# Altium schematic sheet LED Driver.SchDoc("LED Driver")
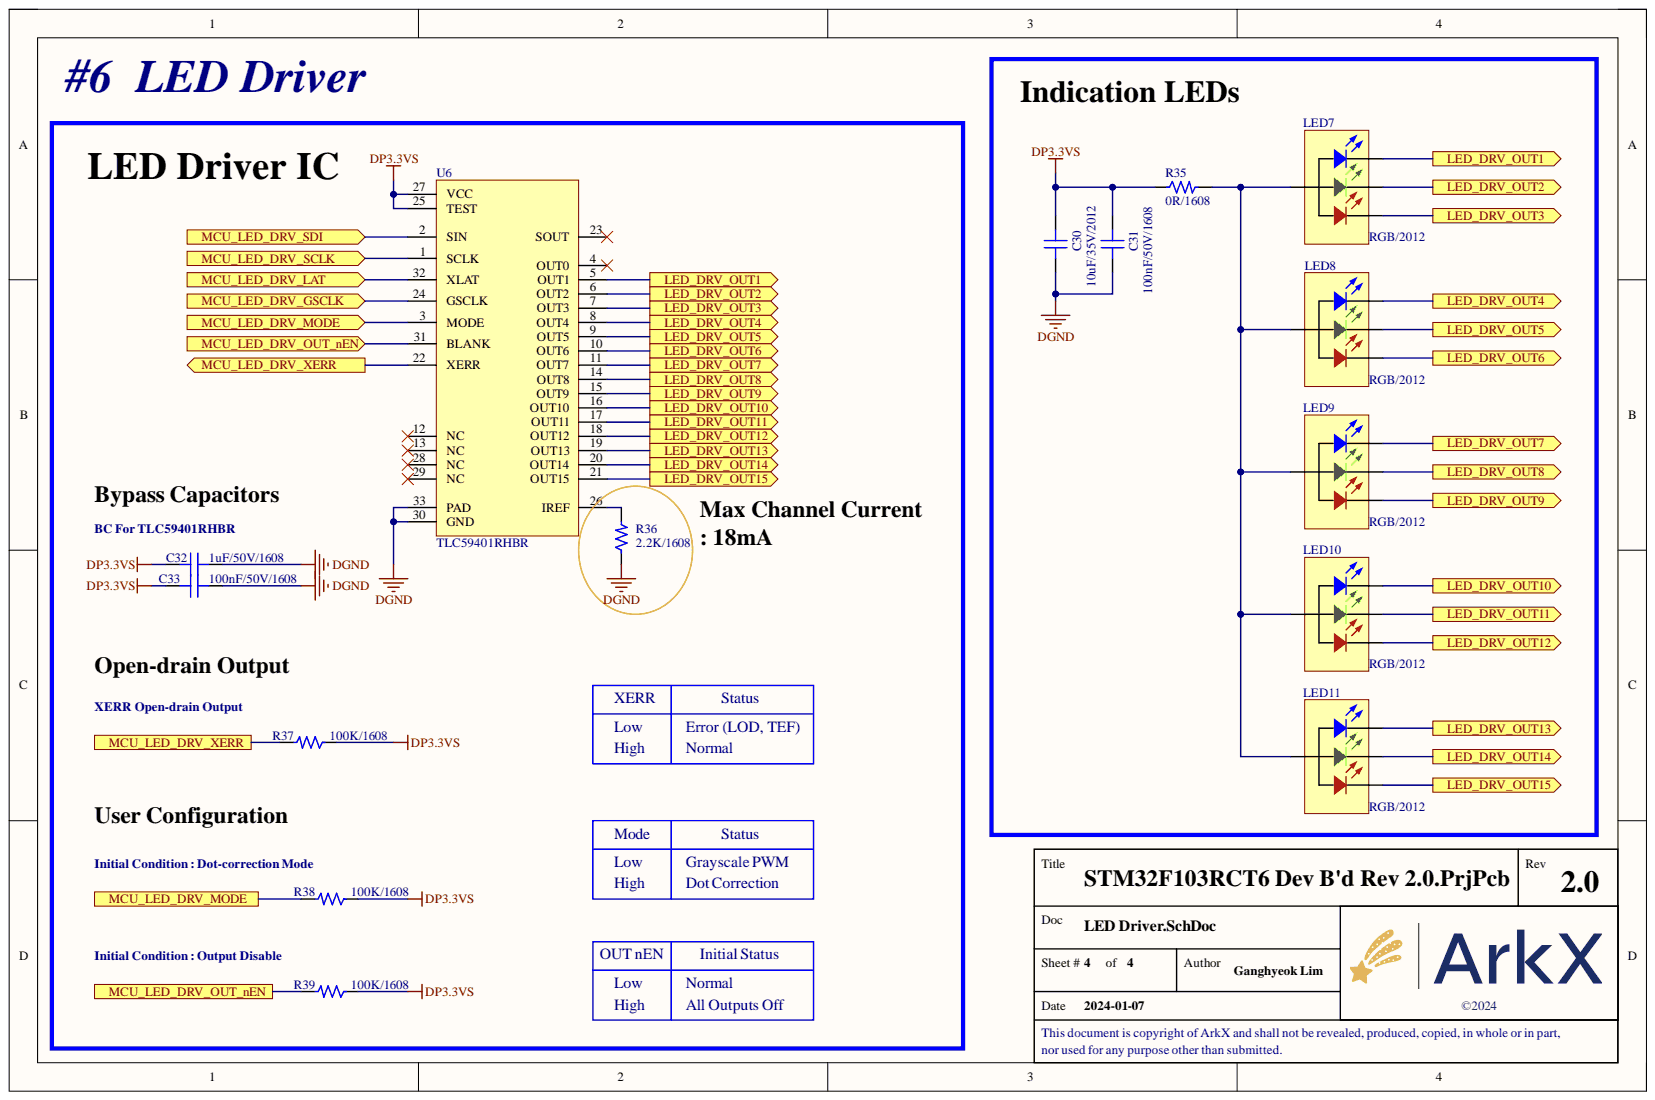
[diagram p0: LED Driver.SchDoc]

## Parts
C30  10uF/35V/2012  [CAP 2012 (0805_INCH)]
C31  100nF/50V/1608  [CAP 1608 (0603_INCH)]
C32  1uF/50V/1608  [CAP 1608 (0603_INCH)]
C33  100nF/50V/1608  [CAP 1608 (0603_INCH)]
LED7  RGB/2012  [LED MHT170RGBCT]
LED8  RGB/2012  [LED MHT170RGBCT]
LED9  RGB/2012  [LED MHT170RGBCT]
LED10  RGB/2012  [LED MHT170RGBCT]
LED11  RGB/2012  [LED MHT170RGBCT]
R35  0R/1608  [RES 1608 (0603_inch)]
R36  2.2K/1608  [RES 1608 (0603_inch)]
R37  100K/1608  [RES 1608 (0603_inch)]
R38  100K/1608  [RES 1608 (0603_inch)]
R39  100K/1608  [RES 1608 (0603_inch)]
U6  TLC59401RHBR  [VQFN-32 (TI drawing - RHB)]

## Ports
LED_DRV_OUT1, LED_DRV_OUT2, LED_DRV_OUT3, LED_DRV_OUT4, LED_DRV_OUT5, LED_DRV_OUT6, LED_DRV_OUT7, LED_DRV_OUT8, LED_DRV_OUT9, LED_DRV_OUT10, LED_DRV_OUT11, LED_DRV_OUT12, LED_DRV_OUT13, LED_DRV_OUT14, LED_DRV_OUT15, MCU_LED_DRV_GSCLK, MCU_LED_DRV_LAT, MCU_LED_DRV_MODE, MCU_LED_DRV_OUT_nEN, MCU_LED_DRV_SCLK, MCU_LED_DRV_SDI, MCU_LED_DRV_XERR

## Nets
DGND: C30.2 C31.1 C32.1 C33.1 R36.1 U6.30(GND) U6.33(PAD)
DP3.3VS: C30.1 C31.2 C32.2 C33.2 R35.1 R37.1 R38.1 R39.1 U6.25(TEST) U6.27(VCC)
LED_DRV_OUT1: LED7.3 U6.5(OUT1) ; port LED_DRV_OUT1
LED_DRV_OUT2: LED7.2 U6.6(OUT2) ; port LED_DRV_OUT2
LED_DRV_OUT3: LED7.1 U6.7(OUT3) ; port LED_DRV_OUT3
LED_DRV_OUT4: LED8.3 U6.8(OUT4) ; port LED_DRV_OUT4
LED_DRV_OUT5: LED8.2 U6.9(OUT5) ; port LED_DRV_OUT5
LED_DRV_OUT6: LED8.1 U6.10(OUT6) ; port LED_DRV_OUT6
LED_DRV_OUT7: LED9.3 U6.11(OUT7) ; port LED_DRV_OUT7
LED_DRV_OUT8: LED9.2 U6.14(OUT8) ; port LED_DRV_OUT8
LED_DRV_OUT9: LED9.1 U6.15(OUT9) ; port LED_DRV_OUT9
LED_DRV_OUT10: LED10.3 U6.16(OUT10) ; port LED_DRV_OUT10
LED_DRV_OUT11: LED10.2 U6.17(OUT11) ; port LED_DRV_OUT11
LED_DRV_OUT12: LED10.1 U6.18(OUT12) ; port LED_DRV_OUT12
LED_DRV_OUT13: LED11.3 U6.19(OUT13) ; port LED_DRV_OUT13
LED_DRV_OUT14: LED11.2 U6.20(OUT14) ; port LED_DRV_OUT14
LED_DRV_OUT15: LED11.1 U6.21(OUT15) ; port LED_DRV_OUT15
MCU_LED_DRV_GSCLK: U6.24(GSCLK) ; port MCU_LED_DRV_GSCLK
MCU_LED_DRV_LAT: U6.32(XLAT) ; port MCU_LED_DRV_LAT
MCU_LED_DRV_MODE: R38.2 U6.3(MODE) ; port MCU_LED_DRV_MODE
MCU_LED_DRV_OUT_nEN: R39.2 U6.31(BLANK) ; port MCU_LED_DRV_OUT_nEN
MCU_LED_DRV_SCLK: U6.1(SCLK) ; port MCU_LED_DRV_SCLK
MCU_LED_DRV_SDI: U6.2(SIN) ; port MCU_LED_DRV_SDI
MCU_LED_DRV_XERR: R37.2 U6.22(XERR) ; port MCU_LED_DRV_XERR
NetLED7_4: LED7.4 LED8.4 LED9.4 LED10.4 LED11.4 R35.2
NetR36_2: R36.2 U6.26(IREF)

Not connected: U6 4(OUT0) 12(NC) 13(NC) 23(SOUT) 28(NC) 29(NC)

## Library data of the parts
C30 = CAP_10uF/35V/2012: Ceramic CAP_10uF/35V/2012; Component Kind: Standard; JLCPCB Part Type: Basic Part; LCSC Part #: C440198; Library Name: Arkx.IntLib
C31 C33 = CAP_100nF/50V/1608: Ceramic CAP_100nF/50V/1608; Component Kind: Standard; JLCPCB Part Type: Basic Part; LCSC Part #: C14663; Library Name: Arkx.IntLib
C32 = CAP_1uF/50V/1608: Ceramic CAP_1uF/50V/1608; Component Kind: Standard; JLCPCB Part Type: Basic Part; LCSC Part #: C15849; Library Name: Arkx.IntLib
LED7-LED11 = LED_RGB_MHT170RGBCT: Colorless transparence -30℃~+85℃ Positive post Common anode RGB 120° 0805  Light Emitting Diodes (LED) ROHS; Component Kind: Standard; JLCPCB Part Ype: Extended Part; LCSC Part #: C2962094; Library Name: Arkx.IntLib
R35 = RES_0R/1608/0.1W: Power Rating: 0.1W; Precision: 1%; Value: 0R; Component Kind: Standard; Description: RES_0R/1608/0.1W; JLCPCB Part Type: Basic Part; LCSC Part #: C21189; Size: 1608; Library Name: Arkx.IntLib
R36 = RES_2.2K/1608/0.1W: Power Rating: 0.1W; Precision: 1%; Value: 2.2K; Component Kind: Standard; Description: RES_2.2K/1608/0.1W; JLCPCB Part Type: Basic Part; LCSC Part #: C4190; Size: 1608; Library Name: Arkx.IntLib
R37-R39 = RES_100K/1608/0.1W: Power Rating: 0.1W; Precision: 1%; Value: 100K; Component Kind: Standard; Description: RES_100K/1608/0.1W; JLCPCB Part Type: Basic Part; LCSC Part #: C25803; Size: 1608; Library Name: Arkx.IntLib
U6 = IC_LED_TLC59401RHBR: IC LED DRVR LINEAR 120MA 32VQFN; Component Kind: Standard; JLCPCB Part Type: Global Parts Sourcing; Library Name: Arkx.IntLib
